# Altium schematic sheet 05 FPGA_BANK34-35.SchDoc
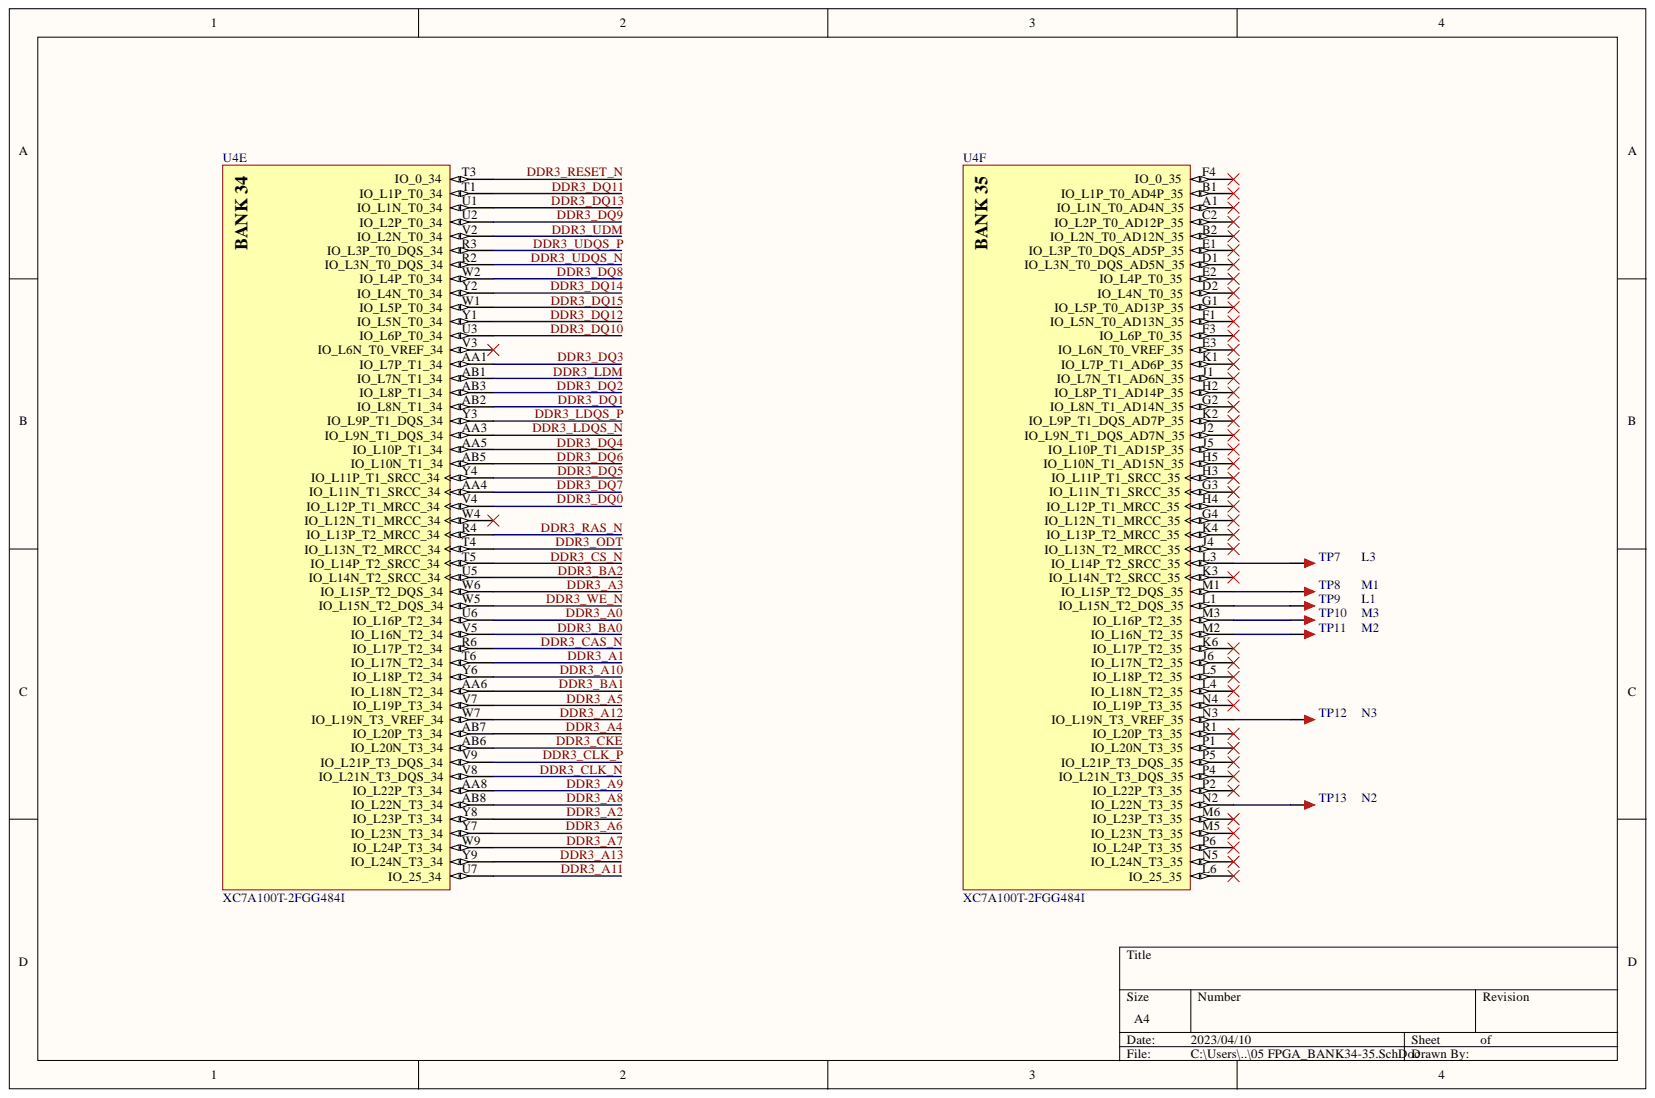
[diagram p0: 05 FPGA_BANK34-35.SchDoc]

## Parts
TP7  L3  [TEST 0.8]
TP8  M1  [TEST 0.8]
TP9  L1  [TEST 0.8]
TP10  M3  [TEST 0.8]
TP11  M2  [TEST 0.8]
TP12  N3  [TEST 0.8]
TP13  N2  [TEST 0.8]
U4  XC7A100T-2FGG484I  [FGG484]  (parts E,F)

## Nets
DDR3_A0: U4.U6(IO_L16P_T2_34)
DDR3_A1: U4.T6(IO_L17N_T2_34)
DDR3_A2: U4.Y8(IO_L23P_T3_34)
DDR3_A3: U4.W6(IO_L15P_T2_DQS_34)
DDR3_A4: U4.AB7(IO_L20P_T3_34)
DDR3_A5: U4.V7(IO_L19P_T3_34)
DDR3_A6: U4.Y7(IO_L23N_T3_34)
DDR3_A7: U4.W9(IO_L24P_T3_34)
DDR3_A8: U4.AB8(IO_L22N_T3_34)
DDR3_A9: U4.AA8(IO_L22P_T3_34)
DDR3_A10: U4.Y6(IO_L18P_T2_34)
DDR3_A11: U4.U7(IO_25_34)
DDR3_A12: U4.W7(IO_L19N_T3_VREF_34)
DDR3_A13: U4.Y9(IO_L24N_T3_34)
DDR3_BA0: U4.V5(IO_L16N_T2_34)
DDR3_BA1: U4.AA6(IO_L18N_T2_34)
DDR3_BA2: U4.U5(IO_L14N_T2_SRCC_34)
DDR3_CAS_N: U4.R6(IO_L17P_T2_34)
DDR3_CKE: U4.AB6(IO_L20N_T3_34)
DDR3_CLK_N: U4.V8(IO_L21N_T3_DQS_34)
DDR3_CLK_P: U4.V9(IO_L21P_T3_DQS_34)
DDR3_CS_N: U4.T5(IO_L14P_T2_SRCC_34)
DDR3_DQ0: U4.V4(IO_L12P_T1_MRCC_34)
DDR3_DQ1: U4.AB2(IO_L8N_T1_34)
DDR3_DQ2: U4.AB3(IO_L8P_T1_34)
DDR3_DQ3: U4.AA1(IO_L7P_T1_34)
DDR3_DQ4: U4.AA5(IO_L10P_T1_34)
DDR3_DQ5: U4.Y4(IO_L11P_T1_SRCC_34)
DDR3_DQ6: U4.AB5(IO_L10N_T1_34)
DDR3_DQ7: U4.AA4(IO_L11N_T1_SRCC_34)
DDR3_DQ8: U4.W2(IO_L4P_T0_34)
DDR3_DQ9: U4.U2(IO_L2P_T0_34)
DDR3_DQ10: U4.U3(IO_L6P_T0_34)
DDR3_DQ11: U4.T1(IO_L1P_T0_34)
DDR3_DQ12: U4.Y1(IO_L5N_T0_34)
DDR3_DQ13: U4.U1(IO_L1N_T0_34)
DDR3_DQ14: U4.Y2(IO_L4N_T0_34)
DDR3_DQ15: U4.W1(IO_L5P_T0_34)
DDR3_LDM: U4.AB1(IO_L7N_T1_34)
DDR3_LDQS_N: U4.AA3(IO_L9N_T1_DQS_34)
DDR3_LDQS_P: U4.Y3(IO_L9P_T1_DQS_34)
DDR3_ODT: U4.T4(IO_L13N_T2_MRCC_34)
DDR3_RAS_N: U4.R4(IO_L13P_T2_MRCC_34)
DDR3_RESET_N: U4.T3(IO_0_34)
DDR3_UDM: U4.V2(IO_L2N_T0_34)
DDR3_UDQS_N: U4.R2(IO_L3N_T0_DQS_34)
DDR3_UDQS_P: U4.R3(IO_L3P_T0_DQS_34)
DDR3_WE_N: U4.W5(IO_L15N_T2_DQS_34)
NetTP7_1: TP7.1 U4.L3(IO_L14P_T2_SRCC_35)
NetTP8_1: TP8.1 U4.M1(IO_L15P_T2_DQS_35)
NetTP9_1: TP9.1 U4.L1(IO_L15N_T2_DQS_35)
NetTP10_1: TP10.1 U4.M3(IO_L16P_T2_35)
NetTP11_1: TP11.1 U4.M2(IO_L16N_T2_35)
NetTP12_1: TP12.1 U4.N3(IO_L19N_T3_VREF_35)
NetTP13_1: TP13.1 U4.N2(IO_L22N_T3_35)

Not connected: U4 A1(IO_L1N_T0_AD4N_35) B1(IO_L1P_T0_AD4P_35) B2(IO_L2N_T0_AD12N_35) C2(IO_L2P_T0_AD12P_35) D1(IO_L3N_T0_DQS_AD5N_35) D2(IO_L4N_T0_35) E1(IO_L3P_T0_DQS_AD5P_35) E2(IO_L4P_T0_35) E3(IO_L6N_T0_VREF_35) F1(IO_L5N_T0_AD13N_35) F3(IO_L6P_T0_35) F4(IO_0_35) G1(IO_L5P_T0_AD13P_35) G2(IO_L8N_T1_AD14N_35) G3(IO_L11N_T1_SRCC_35) G4(IO_L12N_T1_MRCC_35) H2(IO_L8P_T1_AD14P_35) H3(IO_L11P_T1_SRCC_35) H4(IO_L12P_T1_MRCC_35) H5(IO_L10N_T1_AD15N_35) J1(IO_L7N_T1_AD6N_35) J2(IO_L9N_T1_DQS_AD7N_35) J4(IO_L13N_T2_MRCC_35) J5(IO_L10P_T1_AD15P_35) J6(IO_L17N_T2_35) K1(IO_L7P_T1_AD6P_35) K2(IO_L9P_T1_DQS_AD7P_35) K3(IO_L14N_T2_SRCC_35) K4(IO_L13P_T2_MRCC_35) K6(IO_L17P_T2_35) L4(IO_L18N_T2_35) L5(IO_L18P_T2_35) L6(IO_25_35) M5(IO_L23N_T3_35) M6(IO_L23P_T3_35) N4(IO_L19P_T3_35) N5(IO_L24N_T3_35) P1(IO_L20N_T3_35) P2(IO_L22P_T3_35) P4(IO_L21N_T3_DQS_35) P5(IO_L21P_T3_DQS_35) P6(IO_L24P_T3_35) R1(IO_L20P_T3_35) V3(IO_L6N_T0_VREF_34) W4(IO_L12N_T1_MRCC_34)

## Library data of the parts
TP7-TP13 = TEST: 测试点; 版权归属: 源创客; BOM名称: 测试点; Component Kind: Standard; 学习资源: www.ooocke.com; Library Name: 测试调试.IntLib
U4 = XC7A100T-2FGG484I: Artix-7 FPGA, 285 User I/Os, 4 GTP, 484-Ball BGA, Speed Grade 2, Industrial Grade, Pb-Free; Manufacturer: Xilinx; Block RAM Blocks (18Kb): 270; Block RAM Blocks (36Kb): 135; Block RAM Blocks (Max Kb): 4860; CLBs (Slices): 15850; Clock Mgmt Tiles (CMTs): 6; DSP48E1 Slices: 240; Logic Cells: 101440; Max User I/O: 300; Speed Grade: -2; CLBs (Max Distributed RAM Kb): 1188; Code_JEDEC: MS-034-AAJ-1; Component Kind: Standard; ComponentLink1Description: Manufacturer URL; ComponentLink1URL: http://www.xilinx.com/; ComponentLink2Description: Datasheet; ComponentLink2URL: http://www.xilinx.com/support/documentation/data_sheets/ds180_7Series_Overview.pdf; ComponentLink3Description: Package Specification; ComponentLink3URL: http://www.xilinx.com/support/documentation/user_guides/ug475_7Series_Pkg_Pinout.pdf; ComponentLink4Description: DC and Switching Characteristics; ComponentLink4URL: http://www.xilinx.com/support/documentation/data_sheets/ds181_Artix_7_Data_Sheet.pdf; ComponentLink5Description: Package File; ComponentLink5URL: http://www.xilinx.com/support/packagefiles/a7packages/xc7a100tfgg484pkg.txt; ComponentLink6Description: Packaging and Pinout; ComponentLink6URL: http://www.xilinx.com/support/documentation/user_guides/ug475_7Series_Pkg_Pinout.pdf; DatasheetVersion: v1.12; Generic Part Number: XC7A100T; Library Name: Xilinx Artix-7.IntLib; Mounting Technology: Surface Mount; NexusDeviceID: XC7A100T-2FGG484I; PackageDescription: Wire-Bond Fine-Pitch BGA, Body 23 x 23 mm, 1.0 mm Pitch; PackageReference: FGG484; PackageVersion: Rev 1.8; PartNumber: XC7A100T-2FGG484I; RoHS: Pb-Free; Supplier 1: Digi-Key; Supplier Part Number 1: 122-1879-ND
